# Altium schematic sheet ESP32_CAN_V2-mcu.SchDoc
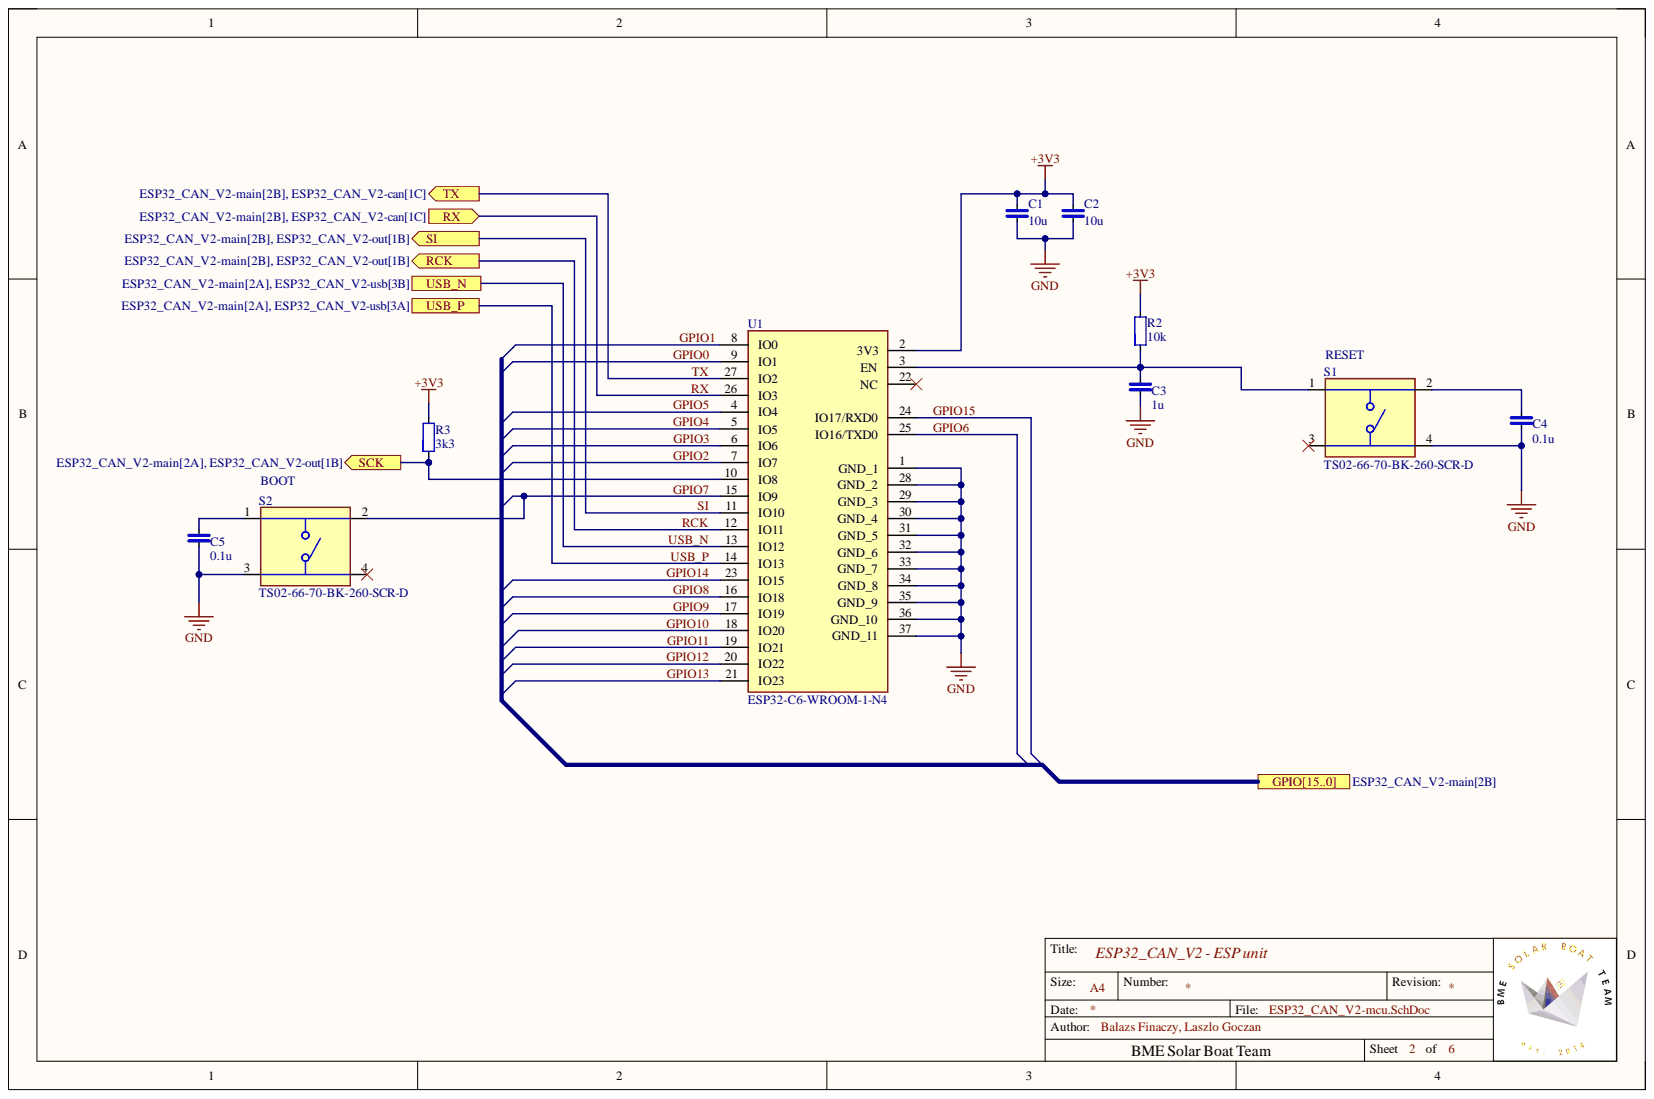
[diagram p0: ESP32_CAN_V2-mcu.SchDoc]

## Parts
C1  10u  [C0805]
C2  10u  [C0805]
C3  1u  [C0603]
C4  0.1u  [C0603]
C5  0.1u  [C0603]
R2  10k  [R0603]
R3  3k3  [R0603]
S1  TS02-66-70-BK-260-SCR-D  [SW_TS02-66-70-BK-260-SCR-D]
S2  TS02-66-70-BK-260-SCR-D  [SW_TS02-66-70-BK-260-SCR-D]
U1  ESP32-C6-WROOM-1-N4  [ESP32C6WROOM1N4]

## Ports
GPIO0, GPIO1, GPIO2, GPIO3, GPIO4, GPIO5, GPIO6, GPIO7, GPIO8, GPIO9, GPIO10, GPIO11, GPIO12, GPIO13, GPIO14, GPIO15, GPIO[15..0], RCK, RX, SCK, SI, TX, USB_N, USB_P

## Nets
+3V3: C1.2 C2.2 R2.2 R3.2 U1.2(3V3)
GND: C1.1 C2.1 C3.1 C4.1 C5.1 S1.4 U1.1(GND_1) U1.28(GND_2) U1.29(GND_3) U1.30(GND_4) U1.31(GND_5) U1.32(GND_6) U1.33(GND_7) U1.34(GND_8) U1.35(GND_9) U1.36(GND_10) U1.37(GND_11)
GPIO0: U1.9(IO1) ; port GPIO[15..0]  [also labelled ]
GPIO1: U1.8(IO0) ; port GPIO[15..0]  [also labelled ]
GPIO2: U1.7(IO7) ; port GPIO[15..0]  [also labelled ]
GPIO3: U1.6(IO6) ; port GPIO[15..0]  [also labelled ]
GPIO4: U1.5(IO5) ; port GPIO[15..0]  [also labelled ]
GPIO5: U1.4(IO4) ; port GPIO[15..0]  [also labelled ]
GPIO6: U1.25(IO16/TXD0) ; port GPIO[15..0]  [also labelled ]
GPIO7: S2.2 U1.15(IO9) ; port GPIO[15..0]  [also labelled ]
GPIO8: U1.16(IO18) ; port GPIO[15..0]  [also labelled ]
GPIO9: U1.17(IO19) ; port GPIO[15..0]  [also labelled ]
GPIO10: U1.18(IO20) ; port GPIO[15..0]  [also labelled ]
GPIO11: U1.19(IO21) ; port GPIO[15..0]  [also labelled ]
GPIO12: U1.20(IO22) ; port GPIO[15..0]  [also labelled ]
GPIO13: U1.21(IO23) ; port GPIO[15..0]  [also labelled ]
GPIO14: U1.23(IO15) ; port GPIO[15..0]  [also labelled ]
GPIO15: U1.24(IO17/RXD0) ; port GPIO[15..0]  [also labelled ]
RCK: U1.12(IO11) ; port RCK
RX: U1.26(IO3) ; port RX
SCK: R3.1 U1.10(IO8) ; port SCK
SI: U1.11(IO10) ; port SI
TX: U1.27(IO2) ; port TX
USB_N: U1.13(IO12) ; port USB_N
USB_P: U1.14(IO13) ; port USB_P
NetC3_2: C3.2 R2.1 S1.1 U1.3(EN)
NetC4_2: C4.2 S1.2
NetC5_2: C5.2 S2.1
NetS1_3: S1.3
NetS2_3: S2.3
NetS2_4: S2.4
NetU1_22: U1.22(NC)

## Library data of the parts
C1-C5 = C: Component Kind: Standard; Library Name: ESP32_CAN_V2.SchLib
R2 R3 = R: Component Kind: Standard; Library Name: ESP32_CAN_V2.SchLib
S1 S2 = TS02-66-70-BK-260-SCR-D: 6 x 6 mm, 7 mm Actuator Height, 260 gf, Black, Short Crimped, Through Hole, SPST, Tactile Switch; MF: Same Sky; Check_prices: https://www.snapeda.com/parts/TS02-66-70-BK-260-SCR-D/Same+Sky/view-part/?ref=eda; Component Kind: Standard; Library Name: ESP32_CAN_V2.SchLib; MP: TS02-66-70-BK-260-SCR-D; Package: None; SnapEDA_Link: https://www.snapeda.com/parts/TS02-66-70-BK-260-SCR-D/Same+Sky/view-part/?ref=snap
U1 = ESP32-C6-WROOM-1-N4_reorder: 802.15.4, Bluetooth, WiFi Bluetooth v5.0, Zigbee Transceiver Module 2.4GHz PCB Trace Surface Mount; Manufacturer_Name: Espressif Systems; Geometry.Height: 3.25mm; Component Kind: Standard; Datasheet Link: https://www.espressif.com/sites/default/files/documentation/esp32-c6-wroom-1_wroom-1u_datasheet_en.pdf; Library Name: ESP32_CAN_V2.SchLib; Manufacturer_Part_Number: ESP32-C6-WROOM-1-N4; Mouser Part Number: 356-ESP32C6WROOM1N4; Mouser Price/Stock: https://www.mouser.co.uk/ProductDetail/Espressif-Systems/ESP32-C6-WROOM-1-N4?qs=8Wlm6%252BaMh8R1JRAtXlt87w%3D%3D
